# Altium schematic sheet Digital Pot U1 and U2.SchDoc
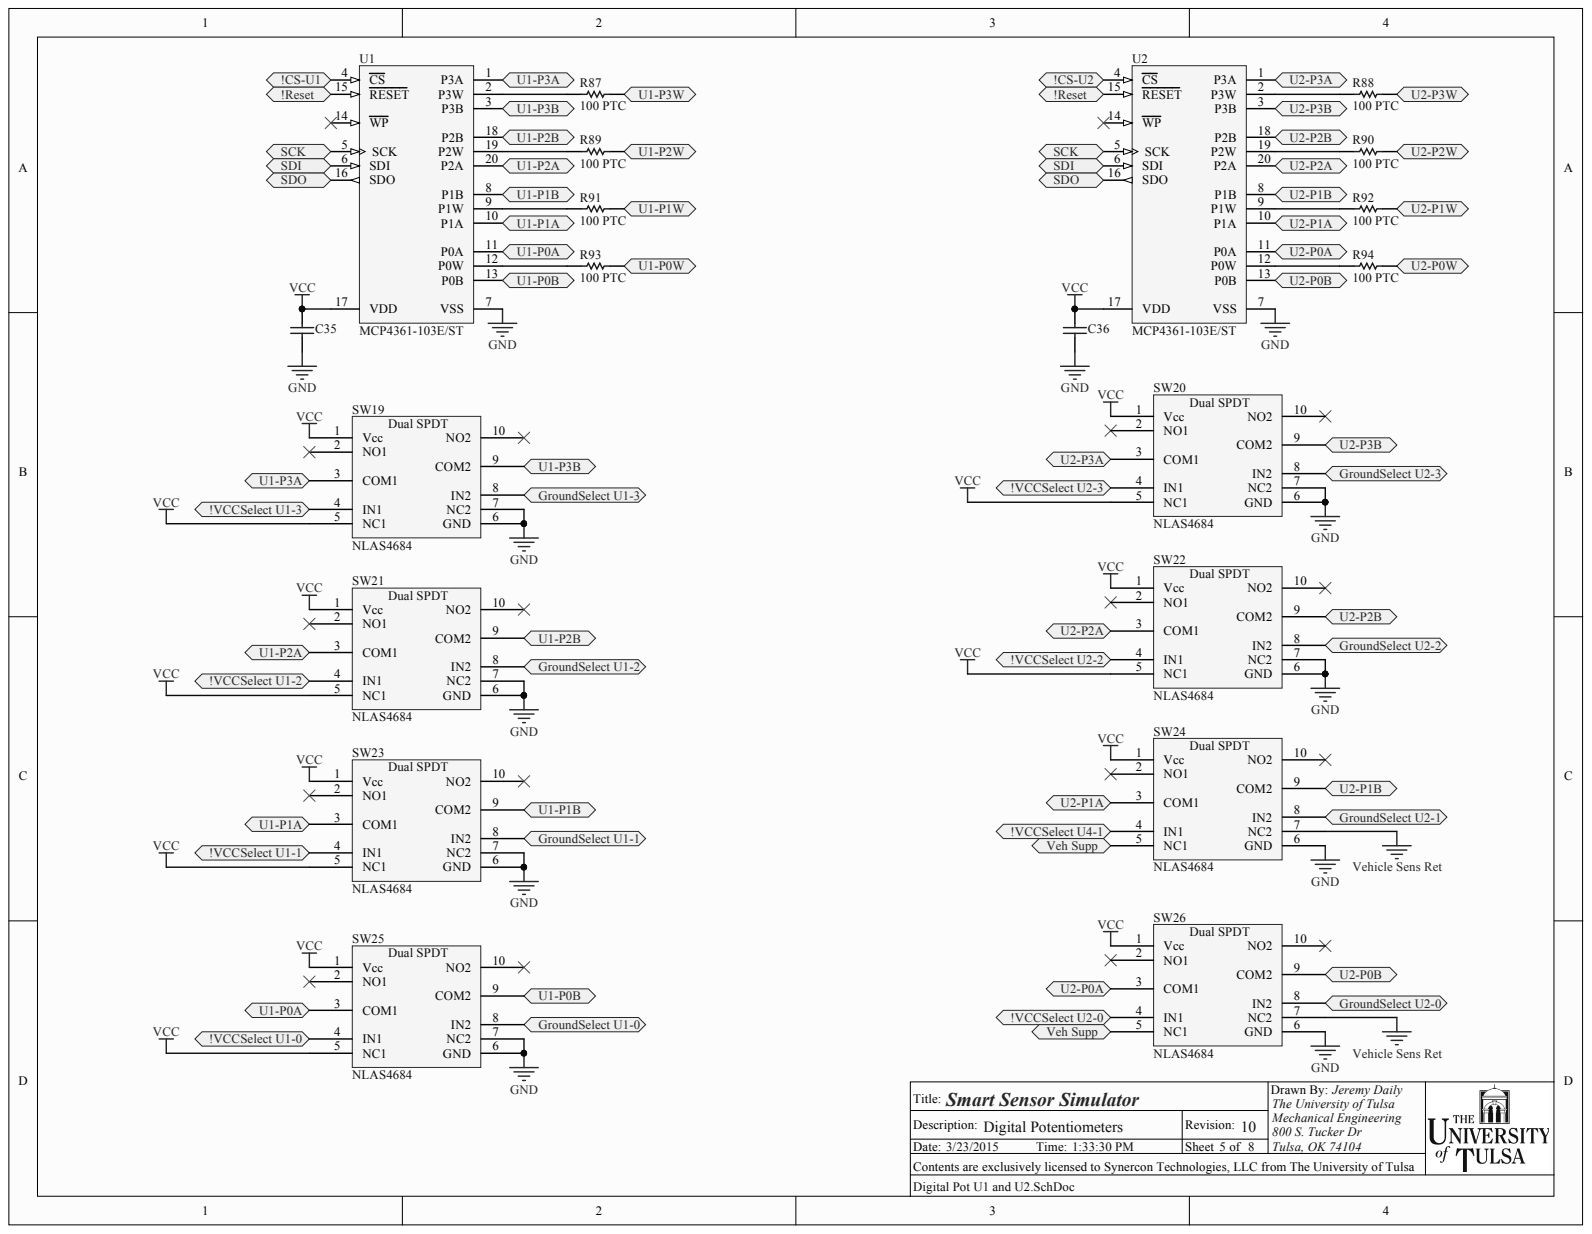
[diagram p0: Digital Pot U1 and U2.SchDoc]

## Parts
C35  0.1uF  [0603]  (value not drawn)
C36  0.1uF  [0603]  (value not drawn)
R87  100 PTC  [0603]
R88  100 PTC  [0603]
R89  100 PTC  [0603]
R90  100 PTC  [0603]
R91  100 PTC  [0603]
R92  100 PTC  [0603]
R93  100 PTC  [0603]
R94  100 PTC  [0603]
SW19  NLAS4684  [ONSC-MICRO-10-846B-03_V]
SW20  NLAS4684  [ONSC-MICRO-10-846B-03_V]
SW21  NLAS4684  [ONSC-MICRO-10-846B-03_V]
SW22  NLAS4684  [ONSC-MICRO-10-846B-03_V]
SW23  NLAS4684  [ONSC-MICRO-10-846B-03_V]
SW24  NLAS4684  [ONSC-MICRO-10-846B-03_V]
SW25  NLAS4684  [ONSC-MICRO-10-846B-03_V]
SW26  NLAS4684  [ONSC-MICRO-10-846B-03_V]
U1  MCP4361-103E/ST  [TSSOP-ST20_M]
U2  MCP4361-103E/ST  [TSSOP-ST20_M]

## Ports
!CS-U1, !CS-U2, !RESET, !VCCSELECT U1-0, !VCCSELECT U1-1, !VCCSELECT U1-2, !VCCSELECT U1-3, !VCCSELECT U2-0, !VCCSELECT U2-2, !VCCSELECT U2-3, !VCCSELECT U4-1, GROUNDSELECT U1-0, GROUNDSELECT U1-1, GROUNDSELECT U1-2, GROUNDSELECT U1-3, GROUNDSELECT U2-0, GROUNDSELECT U2-1, GROUNDSELECT U2-2, GROUNDSELECT U2-3, SCK, SDI, SDO, U1-P0A, U1-P0B, U1-P0W, U1-P1A, U1-P1B, U1-P1W, U1-P2A, U1-P2B, U1-P2W, U1-P3A, U1-P3B, U1-P3W, U2-P0A, U2-P0B, U2-P0W, U2-P1A, U2-P1B, U2-P1W, U2-P2A, U2-P2B, U2-P2W, U2-P3A, U2-P3B, U2-P3W, VEH SUPP

## Nets
GND: C35.2 C36.2 SW19.6(GND) SW19.7(NC2) SW20.6(GND) SW20.7(NC2) SW21.6(GND) SW21.7(NC2) SW22.6(GND) SW22.7(NC2) SW23.6(GND) SW23.7(NC2) SW24.6(GND) SW25.6(GND) SW25.7(NC2) SW26.6(GND) U1.7(VSS) U2.7(VSS)
VCC: C35.1 C36.1 SW19.1(Vcc) SW19.5(NC1) SW20.1(Vcc) SW20.5(NC1) SW21.1(Vcc) SW21.5(NC1) SW22.1(Vcc) SW22.5(NC1) SW23.1(Vcc) SW23.5(NC1) SW24.1(Vcc) SW25.1(Vcc) SW25.5(NC1) SW26.1(Vcc) U1.17(VDD) U2.17(VDD)
VEHICLE SENS RET: SW24.7(NC2) SW26.7(NC2)
!CS-U1: U1.4(CS) ; port !CS-U1
!CS-U2: U2.4(CS) ; port !CS-U2
SCK: U1.5(SCK) U2.5(SCK) ; port SCK
SDI: U1.6(SDI) U2.6(SDI) ; port SDI
SDO: U1.16(SDO) U2.16(SDO) ; port SDO
U1-P0A: SW25.3(COM1) U1.11(P0A) ; port U1-P0A
U1-P0B: SW25.9(COM2) U1.13(P0B) ; port U1-P0B
U1-P0W: R93.2 ; port U1-P0W
U1-P1A: SW23.3(COM1) U1.10(P1A) ; port U1-P1A
U1-P1B: SW23.9(COM2) U1.8(P1B) ; port U1-P1B
U1-P1W: R91.2 ; port U1-P1W
U1-P2A: SW21.3(COM1) U1.20(P2A) ; port U1-P2A
U1-P2B: SW21.9(COM2) U1.18(P2B) ; port U1-P2B
U1-P2W: R89.2 ; port U1-P2W
U1-P3A: SW19.3(COM1) U1.1(P3A) ; port U1-P3A
U1-P3B: SW19.9(COM2) U1.3(P3B) ; port U1-P3B
U1-P3W: R87.2 ; port U1-P3W
U2-P0A: SW26.3(COM1) U2.11(P0A) ; port U2-P0A
U2-P0B: SW26.9(COM2) U2.13(P0B) ; port U2-P0B
U2-P0W: R94.2 ; port U2-P0W
U2-P1A: SW24.3(COM1) U2.10(P1A) ; port U2-P1A
U2-P1B: SW24.9(COM2) U2.8(P1B) ; port U2-P1B
U2-P1W: R92.2 ; port U2-P1W
U2-P2A: SW22.3(COM1) U2.20(P2A) ; port U2-P2A
U2-P2B: SW22.9(COM2) U2.18(P2B) ; port U2-P2B
U2-P2W: R90.2 ; port U2-P2W
U2-P3A: SW20.3(COM1) U2.1(P3A) ; port U2-P3A
U2-P3B: SW20.9(COM2) U2.3(P3B) ; port U2-P3B
U2-P3W: R88.2 ; port U2-P3W
NetR87_1: R87.1 U1.2(P3W)
NetR88_1: R88.1 U2.2(P3W)
NetR89_1: R89.1 U1.19(P2W)
NetR90_1: R90.1 U2.19(P2W)
NetR91_1: R91.1 U1.9(P1W)
NetR92_1: R92.1 U2.9(P1W)
NetR93_1: R93.1 U1.12(P0W)
NetR94_1: R94.1 U2.12(P0W)
NetSW19_4: SW19.4(IN1) ; port !VCCSELECT U1-3
NetSW19_8: SW19.8(IN2) ; port GROUNDSELECT U1-3
NetSW20_4: SW20.4(IN1) ; port !VCCSELECT U2-3
NetSW20_8: SW20.8(IN2) ; port GROUNDSELECT U2-3
NetSW21_4: SW21.4(IN1) ; port !VCCSELECT U1-2
NetSW21_8: SW21.8(IN2) ; port GROUNDSELECT U1-2
NetSW22_4: SW22.4(IN1) ; port !VCCSELECT U2-2
NetSW22_8: SW22.8(IN2) ; port GROUNDSELECT U2-2
NetSW23_4: SW23.4(IN1) ; port !VCCSELECT U1-1
NetSW23_8: SW23.8(IN2) ; port GROUNDSELECT U1-1
NetSW24_4: SW24.4(IN1) ; port !VCCSELECT U4-1
NetSW24_5: SW24.5(NC1) SW26.5(NC1) ; port VEH SUPP
NetSW24_8: SW24.8(IN2) ; port GROUNDSELECT U2-1
NetSW25_4: SW25.4(IN1) ; port !VCCSELECT U1-0
NetSW25_8: SW25.8(IN2) ; port GROUNDSELECT U1-0
NetSW26_4: SW26.4(IN1) ; port !VCCSELECT U2-0
NetSW26_8: SW26.8(IN2) ; port GROUNDSELECT U2-0
NetU1_15: U1.15(RESET) U2.15(RESET) ; port !RESET

Not connected: SW19 2(NO1) 10(NO2); SW20 2(NO1) 10(NO2); SW21 2(NO1) 10(NO2); SW22 2(NO1) 10(NO2); SW23 2(NO1) 10(NO2); SW24 2(NO1) 10(NO2); SW25 2(NO1) 10(NO2); SW26 2(NO1) 10(NO2); U1 14(WP); U2 14(WP)

## Library data of the parts
C35 C36 = Cap: CAP CER 0.1UF 50V 10% X7R 0603; Manufacturer: Murata Electronics North America; PartNumber: GRM188R71H104KA93D; Component Kind: Standard; Library Name: SSS Schematic Library.SchLib; Signal Integrity: Cap; Simulation: CAP; Supplier 1: Digi-Key; Supplier Part Number 1: 490-1519-1-ND
R87-R94 = Chip Resistor: THERMISTOR PTC 100 OHM SMD; Manufacturer: Murata Electronics North America; PartNumber: PRG18BB101MB1RB; Component Kind: Standard; Library Name: SSS Schematic Library.SchLib; Supplier 1: Digi-Key; Supplier Part Number 1: 490-2469-1-ND
SW19-SW26 = Dual SPDT: IC SWITCH DUAL SPDT MICRO10; Manufacturer: ON Semiconductor; PartNumber: NLAS4684MR2G; Component Kind: Standard; Library Name: SSS Schematic Library.SchLib; Mounting Technology: Surface Mount; Supplier 1: Digi-Key; Supplier Part Number 1: NLAS4684MR2GOSCT-ND
U1 U2 = MCP4361-103E/ST: 7/8-Bit Quad SPI Digital POT with Non-Volatile Memory, 20-Pin TSSOP, Extended Temperature; Manufacturer: Microchip; Component Kind: Standard; ComponentLink2URL: http://ww1.microchip.com/downloads/en/DeviceDoc/22233a.pdf; ComponentLink3Description: Package Specification; ComponentLink3URL: http://www.microchip.com/stellent/groups/techpub_sg/documents/packagingspec/en012702.pdf; DatasheetVersion: revA, Dec-2009; Mounting Technology: Surface Mount; PackageDescription: 20-Lead Plastic Thin Shrink Small Outline (ST) - 4.4mm Body [TSSOP]; PackageVersion: revBB, Aug-2009; PartNumber: MCP4361-103E/ST; Supplier 1: Digi-Key; Supplier 2: Farnell; Supplier 3: Newark; Supplier 4: Mouser; Supplier Part Number 1: MCP4361-103E/ST-ND; Supplier Part Number 2: 1825025; Supplier Part Number 3: 53R0040; Supplier Part Number 4: 579-MCP4361103E/ST; Library Name: Microchip Mixed Signal.IntLib
